# Altium schematic sheet Motor A Driver.SchDoc("Motor A Driver")
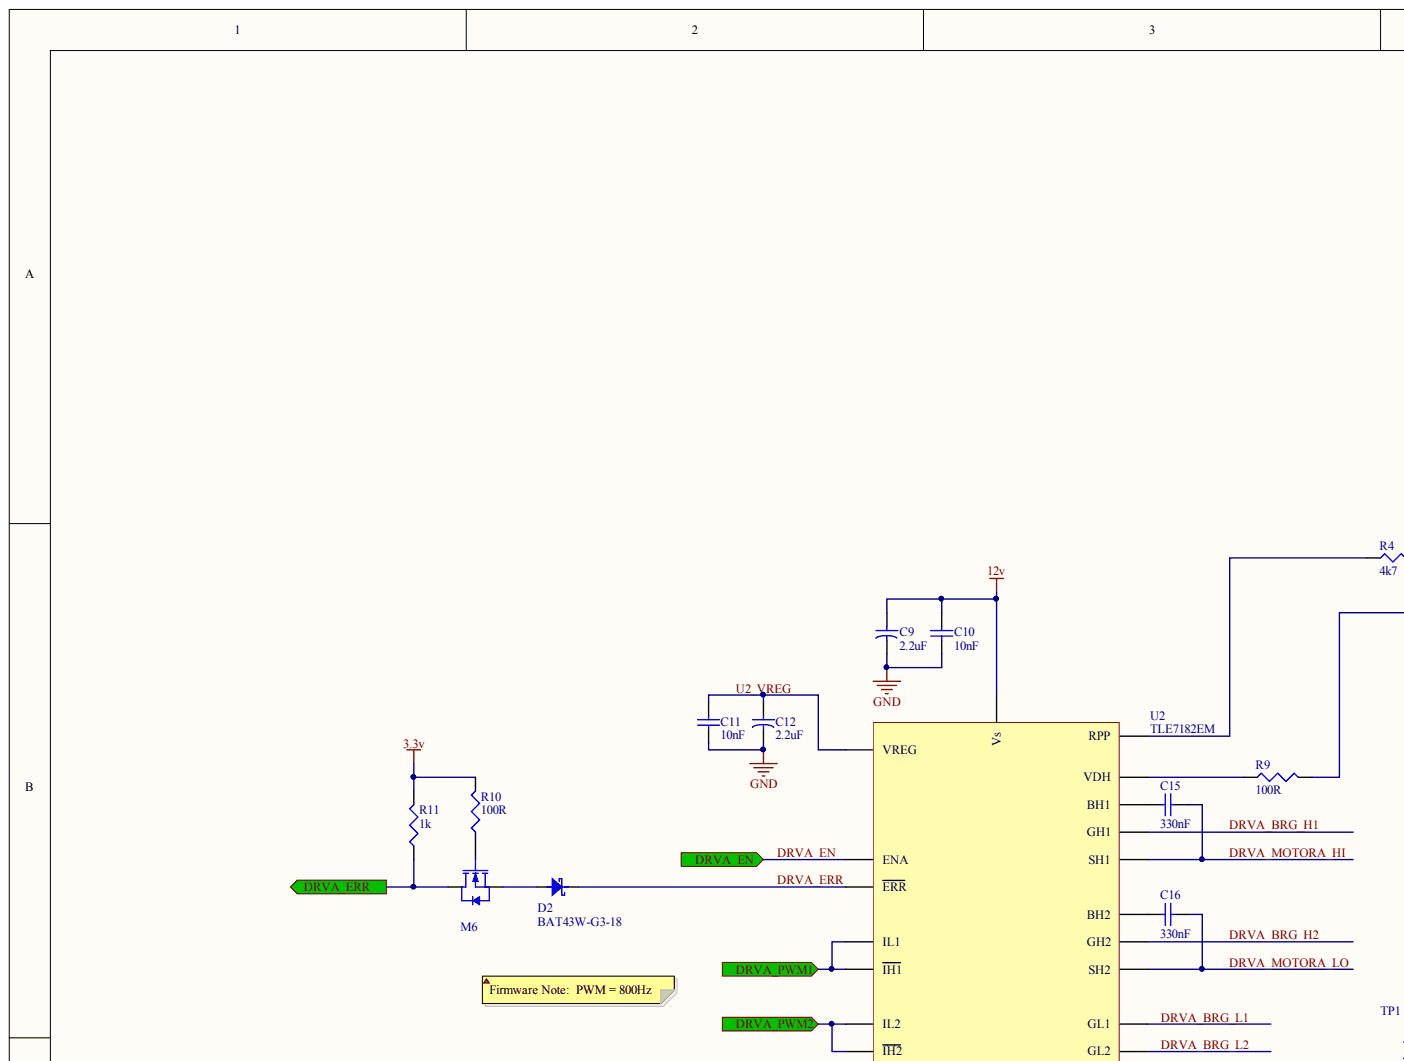
[diagram p0: Motor A Driver.SchDoc, top left]
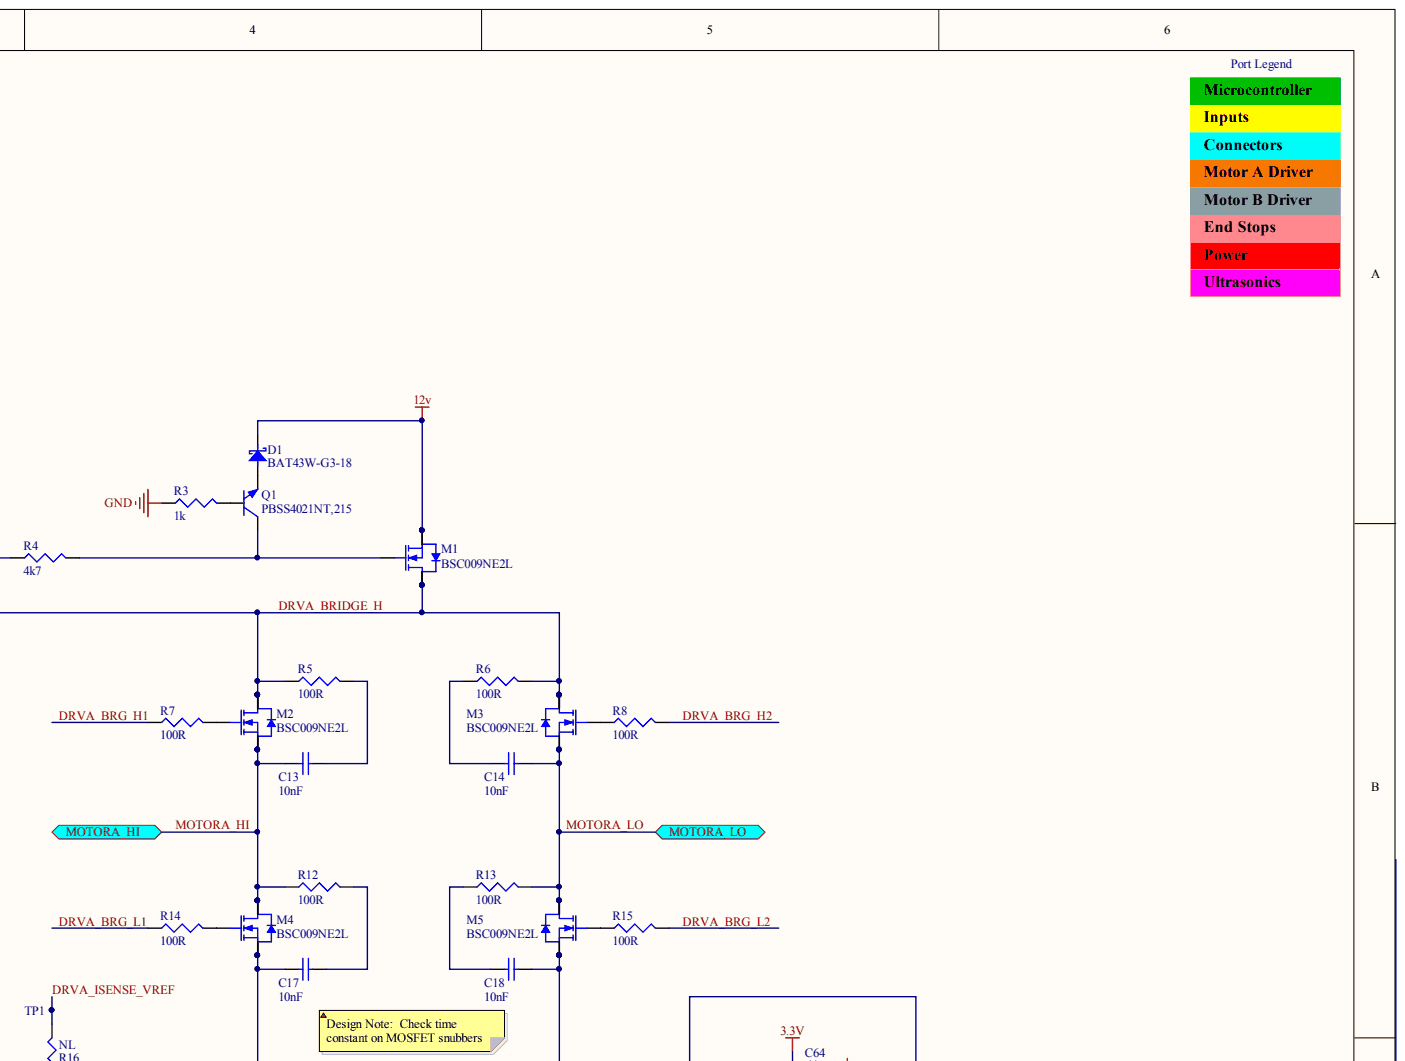
[diagram p1: Motor A Driver.SchDoc, top right]
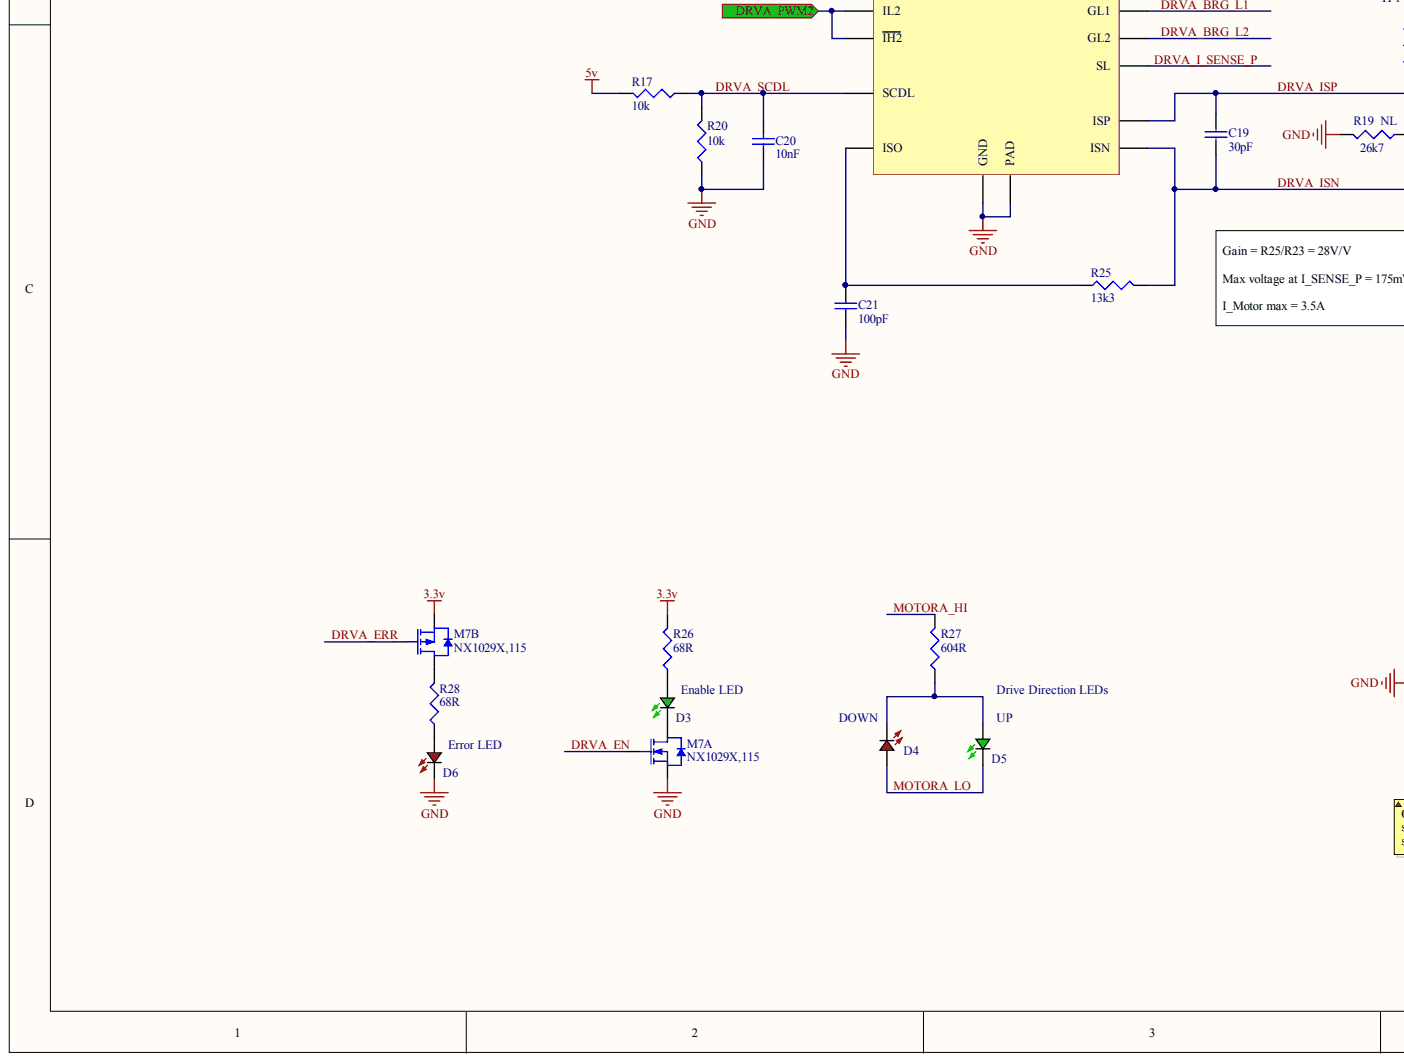
[diagram p2: Motor A Driver.SchDoc, bottom left]
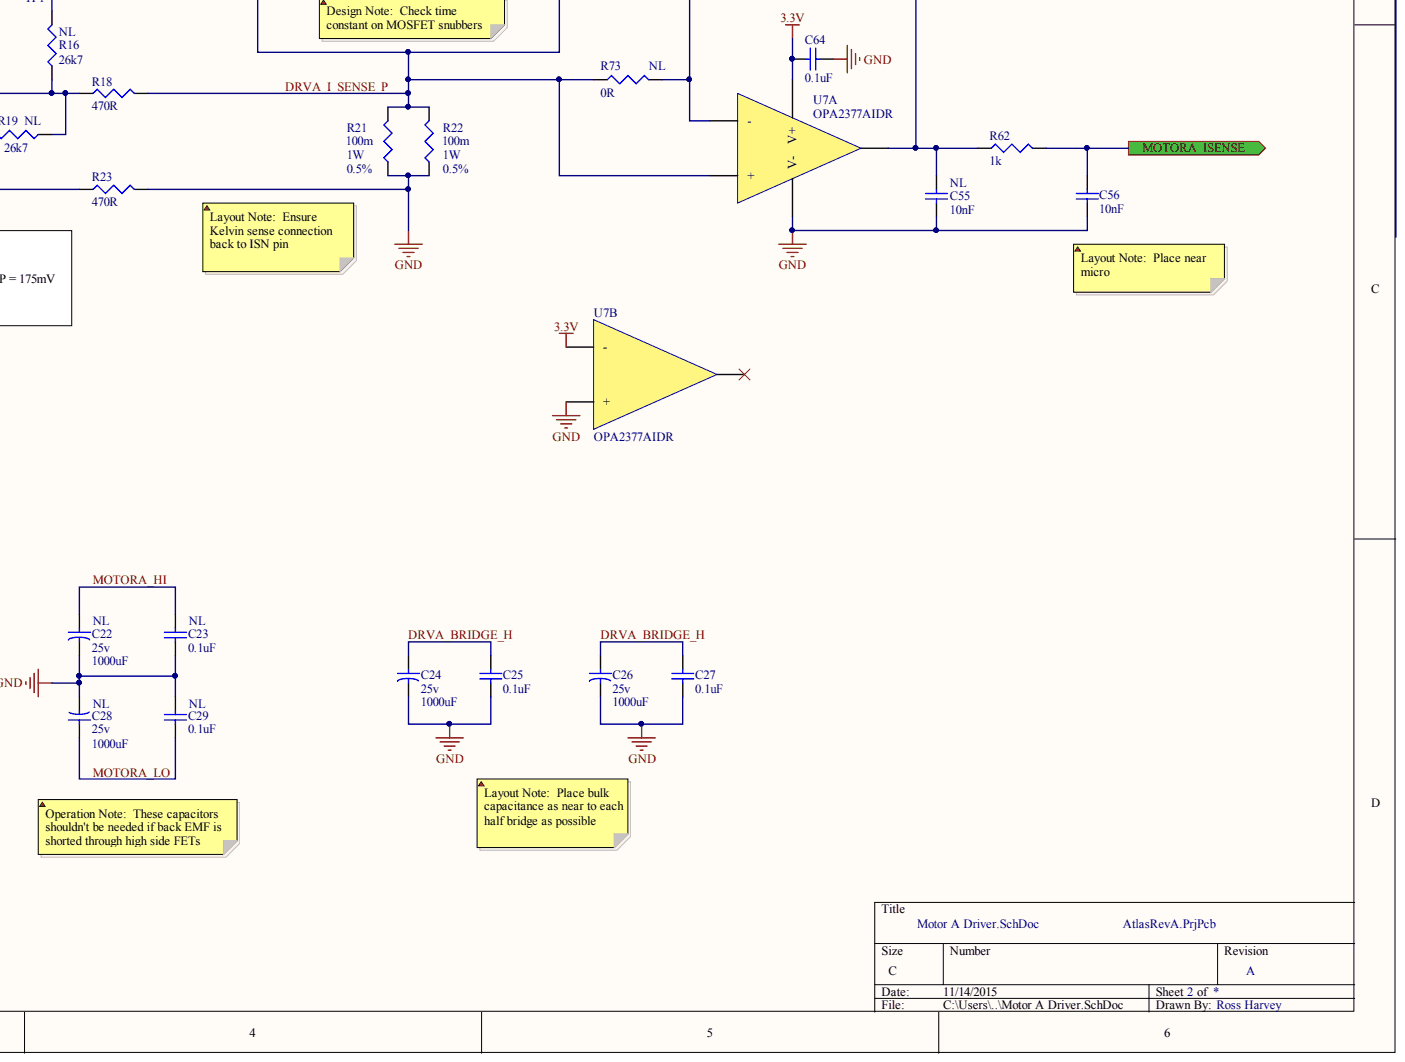
[diagram p3: Motor A Driver.SchDoc, bottom right]

## Parts
C9  2.2uF  [SMD Elec Cap (5.5_5.4_4)]
C10  10nF  [CAP0603W]
C11  10nF  [CAP0603W]
C12  2.2uF  [SMD Elec Cap (5.5_5.4_4)]
C13  10nF  [CAP0603W]
C14  10nF  [CAP0603W]
C15  330nF  [CAP0805W]
C16  330nF  [CAP0805W]
C17  10nF  [CAP0603W]
C18  10nF  [CAP0603W]
C19  30pF  [CAP0603W]
C20  10nF  [CAP0603W]
C21  100pF  [CAP0603W]
C22  1000uF  LOAD: NL  Voltage: 25v  [SMD Elec Cap (13.5_15_12.5)]
C23  0.1uF  LOAD: NL  [CAP0603W]
C24  1000uF  Voltage: 25v  [SMD Elec Cap (13.5_15_12.5)]
C25  0.1uF  [CAP0603W]
C26  1000uF  Voltage: 25v  [SMD Elec Cap (13.5_15_12.5)]
C27  0.1uF  [CAP0603W]
C28  1000uF  LOAD: NL  Voltage: 25v  [SMD Elec Cap (13.5_15_12.5)]
C29  0.1uF  LOAD: NL  [CAP0603W]
C55  10nF  LOAD: NL  [CAP0603W]
C56  10nF  [CAP0603W]
C64  0.1uF  [CAP0603W]
D1  BAT43W-G3-18  [SOD-123]
D2  BAT43W-G3-18  [SOD-123]
D3  LTST-S270KGKT  [LED Green 0603]  (value not drawn)
D4  VLMS1300-GS08  [LED Red 0603]  (value not drawn)
D5  LTST-S270KGKT  [LED Green 0603]  (value not drawn)
D6  VLMS1300-GS08  [LED Red 0603]  (value not drawn)
M1  BSC009NE2L  [PowerPAK-SO8]
M2  BSC009NE2L  [PowerPAK-SO8]
M3  BSC009NE2L  [PowerPAK-SO8]
M4  BSC009NE2L  [PowerPAK-SO8]
M5  BSC009NE2L  [PowerPAK-SO8]
M6  2N7002P,215  [SOT23-3M]  (value not drawn)
M7  NX1029X,115  [SOT666-6N]  (parts A,B)
Q1  PBSS4021NT,215  [SOT23-3M]
R3  1k  [RES0603W]
R4  4k7  [RES0603W]
R5  100R  [RES0603W]
R6  100R  [RES0603W]
R7  100R  [RES0603W]
R8  100R  [RES0603W]
R9  100R  [RES0603W]
R10  100R  [RES0603W]
R11  1k  [RES0603W]
R12  100R  [RES0603W]
R13  100R  [RES0603W]
R14  100R  [RES0603W]
R15  100R  [RES0603W]
R16  26k7  Load: NL  [RES0603W]
R17  10k  [RES0603W]
R18  470R  [RES0603W]
R19  26k7  Load: NL  [RES0603W]
R20  10k  [RES0603W]
R21  100m  POWER: 1W  Tolerance: 0.5%  [RES2512W]
R22  100m  POWER: 1W  Tolerance: 0.5%  [RES2512W]
R23  470R  [RES0603W]
R25  13k3  [RES0603W]
R26  68R  [RES0603W]
R27  604R  [RES1206W]
R28  68R  [RES0603W]
R62  1k  [RES0603W]
R73  0R  Load: NL  [RES0603W]
TP1  TP  [1mm Via]
U2  TLE7182EM  [PG-SSOP24]
U7  OPA2377AIDR  [SOIC-8]  (parts A,B)

## Ports
DRVA_EN, DRVA_ERR, DRVA_PWM1, DRVA_PWM2, MOTORA_HI, MOTORA_ISENSE, MOTORA_LO

## Nets
3.3V: C64.1 M7.4 R10.2 R11.2 R26.2 U7.6(-) U7.8(V+)
5V: R17.2
12V: C9.2 C10.1 D1.1 M1.1 M1.2 M1.3 U2.7(Vs)
GND: C9.1 C10.2 C11.2 C12.1 C20.2 C21.2 C22.1 C23.2 C24.1 C25.2 C26.1 C27.2 C28.1 C29.1 C55.2 C56.2 C64.2 D6.1 M7.1 R3.1 R19.2 R20.1 R21.1 R22.1 R23.2 U2.19(GND) U2.25(PAD) U7.4(V-) U7.5(+)
DRVA_BRG_H1: R7.1 U2.23(GH1)
DRVA_BRG_H2: R8.2 U2.2(GH2)
DRVA_BRG_L1: R14.1 U2.21(GL1)
DRVA_BRG_L2: R15.2 U2.4(GL2)
DRVA_BRIDGE_H: C24.2 C25.1 C26.2 C27.1 M1.5 M1.6 M1.7 M1.8 M2.5 M2.6 M2.7 M2.8 M3.5 M3.6 M3.7 M3.8 R5.1 R6.2 R9.2
DRVA_EN: M7.2 U2.9(ENA) ; port DRVA_EN
DRVA_ERR: D2.1 M7.5 U2.17(ERR)
DRVA_I_SENSE_P: C17.1 C18.2 M4.1 M4.2 M4.3 M5.1 M5.2 M5.3 R18.2 R21.2 R22.2 R73.1 U2.20(SL) U7.3(+)
DRVA_ISENSE_VREF: R16.2 TP1.1
DRVA_ISN: C19.2 R23.1 R25.2 U2.10(ISN)
DRVA_ISP: C19.1 R16.1 R18.1 R19.1 U2.11(ISP)
DRVA_MOTORA_HI: C15.2 U2.22(SH1)
DRVA_MOTORA_LO: C16.2 U2.3(SH2)
DRVA_PWM1: U2.15(IH1) U2.16(IL1) ; port DRVA_PWM1
DRVA_PWM2: U2.13(IH2) U2.14(IL2) ; port DRVA_PWM2
DRVA_SCDL: C20.1 R17.1 R20.2 U2.18(SCDL)
MOTORA_HI: C13.1 C22.2 C23.1 M2.1 M2.2 M2.3 M4.5 M4.6 M4.7 M4.8 R12.1 R27.2 ; port MOTORA_HI
MOTORA_ISENSE: C56.1 R62.2 ; port MOTORA_ISENSE
MOTORA_LO: C14.2 C28.2 C29.2 D4.2 D5.1 M3.1 M3.2 M3.3 M5.5 M5.6 M5.7 M5.8 R13.2 ; port MOTORA_LO
U2_VREG: C11.1 C12.2 U2.8(VREG)
NetC13_2: C13.2 R5.2
NetC14_1: C14.1 R6.1
NetC15_1: C15.1 U2.24(BH1)
NetC16_1: C16.1 U2.1(BH2)
NetC17_2: C17.2 R12.2
NetC18_1: C18.1 R13.1
NetC21_1: C21.1 R25.1 U2.12(ISO)
NetC55_1: C55.1 R62.1 R73.2 U7.1 U7.2(-)
NetD1_2: D1.2 Q1.2
NetD2_2: D2.2 M6.2
NetD3_1: D3.1 M7.6
NetD3_2: D3.2 R26.1
NetD4_1: D4.1 D5.2 R27.1
NetD6_2: D6.2 R28.1
NetM1_4: M1.4 Q1.3 R4.2
NetM2_4: M2.4 R7.2
NetM3_4: M3.4 R8.1
NetM4_4: M4.4 R14.2
NetM5_4: M5.4 R15.1
NetM6_1: M6.1 R10.1
NetM6_3: M6.3 R11.1 ; port DRVA_ERR
NetM7_3: M7.3 R28.2
NetQ1_1: Q1.1 R3.2
NetR4_1: R4.1 U2.6(RPP)
NetR9_1: R9.1 U2.5(VDH)
N00228:

Not connected: U7 7

## Library data of the parts
C9 C12 = 2.2uF/35v: MFG1: PANASONIC; MFG1_PN: EEE-FC1V2R2AR; Tolerance: 20%; Voltage: 35v; Component Kind: Standard; Library Name: Altium Library.IntLib; Value: 2.2uF
C10 C11 C13 C14 C17 C18 C20 C55 C56 = 10nF: MFG1: Vishay; MFG1_PN: VJ0603Y103KXAAC; Component Kind: Standard; Library Name: Altium Library.IntLib; Value: 10nF
C15 C16 = 330nF: MFG1: Vishay; MFG1_PN: VJ0805V334ZXAPW1BC; Voltage: 50v; Component Kind: Standard; Library Name: Altium Library.IntLib; Value: 330nF
C19 = 30pF: MFG1: Vishay; MFG1_PN: VJ0805A300JXBAT; Component Kind: Standard; Library Name: Altium Library.IntLib; Value: 30pF
C21 = 100pF: MFG1: Vishay; MFG1_PN: VJ0603A101JNAAO; Voltage: 50v; Component Kind: Standard; Library Name: Altium Library.IntLib; Value: 100pF
C22 C24 C26 C28 = 1000uF 25v: MFG1: PANASONIC; MFG1_PN: EEV-FK1E102Q; Tolerance: 20%; Component Kind: Standard; Library Name: Altium Library.IntLib; Value: 1000uF
C23 C25 C27 C29 C64 = 0.1uF: MFG1: Vishay; MFG1_PN: VJ0603Y104JXJPW1BC; Component Kind: Standard; Library Name: Altium Library.IntLib; Value: 0.1uF
D1 D2 = BAT43: MFG: Vishay; Component Kind: Standard; Library Name: Altium Library.IntLib; MFG_PN: BAT43W-G3-18
D3 D5 = LED Green 0603: COLOR: Green; CURRENT: 20mA; MFG: Lite On; VFORWARD: 2v; Component Kind: Standard; Library Name: Altium Library.IntLib; MFG_PN: LTST-S270KGKT
D4 D6 = LED Red 0603: COLOR: Red; CURRENT: 20mA; MFG: Vishay; VFORWARD: 2V; Component Kind: Standard; Library Name: Altium Library.IntLib; MFG_PN: VLMS1300-GS08
M1-M5 = BSC009NE2L: NFET_SINGLE_100A_25v; I_MAX: 100A; LOAD: NL; MFG: INFINEON; MFG_PN: BSC009NE2LS5ATMA1; P_MAX: 74W; RDS_ON: 1.25m; VDS_MAX: 25v; VGS_MAX: 16; Component Kind: Standard; Library Name: Altium Library.IntLib
M6 = 2N7002: NFET_SINGLE_360mA_60V; I_MAX: 360mA; LOAD: NL; MFG: NXP; VDS_MAX: 60v; VGS_MAX: 20v; Component Kind: Standard; Library Name: Altium Library.IntLib; MFG_PN: 2N7002P,215
M7 = NX1029X: Complementary P/NFET; ID_N: 330mA; ID_P: -170mA; MFG: NXP; VDS_N: 60v; VDS_P: -50v; VGS: 20v; Component Kind: Standard; Library Name: Altium Library.IntLib; MFG_PN: NX1029X,115
Q1 = PBSS4021NT: NPN_SINGLE_8A_20v; IMAX: 8A; LOAD: NL; MFG: NXP; VCE_MAX: 20v; Component Kind: Standard; Library Name: Altium Library.IntLib; MFG_PN: PBSS4021NT,215
R3 R11 R62 = 1k (0603): Load: NL; MFG: Vishay; MFG_PN: CRCW06031K00FKEA; POWER: 0.1W; Tolerance: 1%; Component Kind: Standard; Library Name: Altium Library.IntLib; Value: 1k
R4 = 4k7 (0603): Load: NL; MFG: Vishay; MFG_PN: CRCW06034K70FKEA; POWER: 0.1W; Tolerance: 1%; Component Kind: Standard; Library Name: Altium Library.IntLib; Value: 4k7
R5-R10 R12-R15 = 100R (0603): Load: NL; MFG: Vishay; MFG_PN: CRCW0603100RFKEA; POWER: 0.1W; Tolerance: 1%; Component Kind: Standard; Library Name: Altium Library.IntLib; Value: 100R
R16 R19 = 26k7 (0603): MFG: Vishay; MFG_PN: CRCW060326K7FKEA; POWER: 0.1W; Tolerance: 1%; Component Kind: Standard; Library Name: Altium Library.IntLib; Value: 26k7
R17 R20 = 10K (0603): Load: NL; MFG: Vishay; MFG_PN: CRCW060310K0FKEA; POWER: 0.1W; Tolerance: 1%; Component Kind: Standard; Library Name: Altium Library.IntLib; Value: 10k
R18 R23 = 470R (0603): Load: NL; MFG: Vishay; MFG_PN: CRCW0603470RFKEA; POWER: 0.1W; Tolerance: 1%; Component Kind: Standard; Library Name: Altium Library.IntLib; Value: 470R
R21 R22 = 100mR 0.5% (2512): Load: NL; MFG: Vishay; MFG_PN: WSL2512R1000DEA; Component Kind: Standard; Library Name: Altium Library.IntLib; Value: 100m
R25 = 13k3 (0603): Load: NL; MFG: Vishay; MFG_PN: CRCW060313K3FKEA; POWER: 0.1W; Tolerance: 1%; Component Kind: Standard; Library Name: Altium Library.IntLib; Value: 13k3
R26 R28 = 68R (0603): Load: NL; MFG: Vishay; MFG_PN: CRCW060368R0FKEB; POWER: 0.1W; Tolerance: 1%; Component Kind: Standard; Library Name: Altium Library.IntLib; Value: 68R
R27 = 604R (1206): Load: NL; MFG: VISHAY; MFG_PN: CRCW1206604RFKEAHP; POWER: 0.5W; Tolerance: 1%; Component Kind: Standard; Library Name: Altium Library.IntLib; Value: 604R
R73 = 0R (0603): MFG: Vishay; MFG_PN: CRCW12060000Z0EA; Component Kind: Standard; Library Name: Altium Library.IntLib; Value: 0R
TP1 = Testpoint - Via 1mm: Component Kind: Standard; Library Name: Altium Library.IntLib
U2 = TLE7182: Full Bridge Gate Driver; LOAD: NL; MFG: INFINEON; Component Kind: Standard; Library Name: Altium Library.IntLib; MFG_PN: TLE7182EM
U7 = OPA2377AIDR: OpAmp - 2 Channel; CHANNELS: 2; GBP: 5MHz; LOAD: NL; MFG: TI; OFFSET_VOLTAGE: 1mV; SINGLE_SUPPLY: TRUE; VMAX: 5.5v; Component Kind: Standard; Library Name: Altium Library.IntLib; MFG_PN: OPA2377AIDR
